# Altium schematic sheet 5.04.51805A02_STMIOPORT.SchDoc(5.04.51805A02_STMIOPORT)
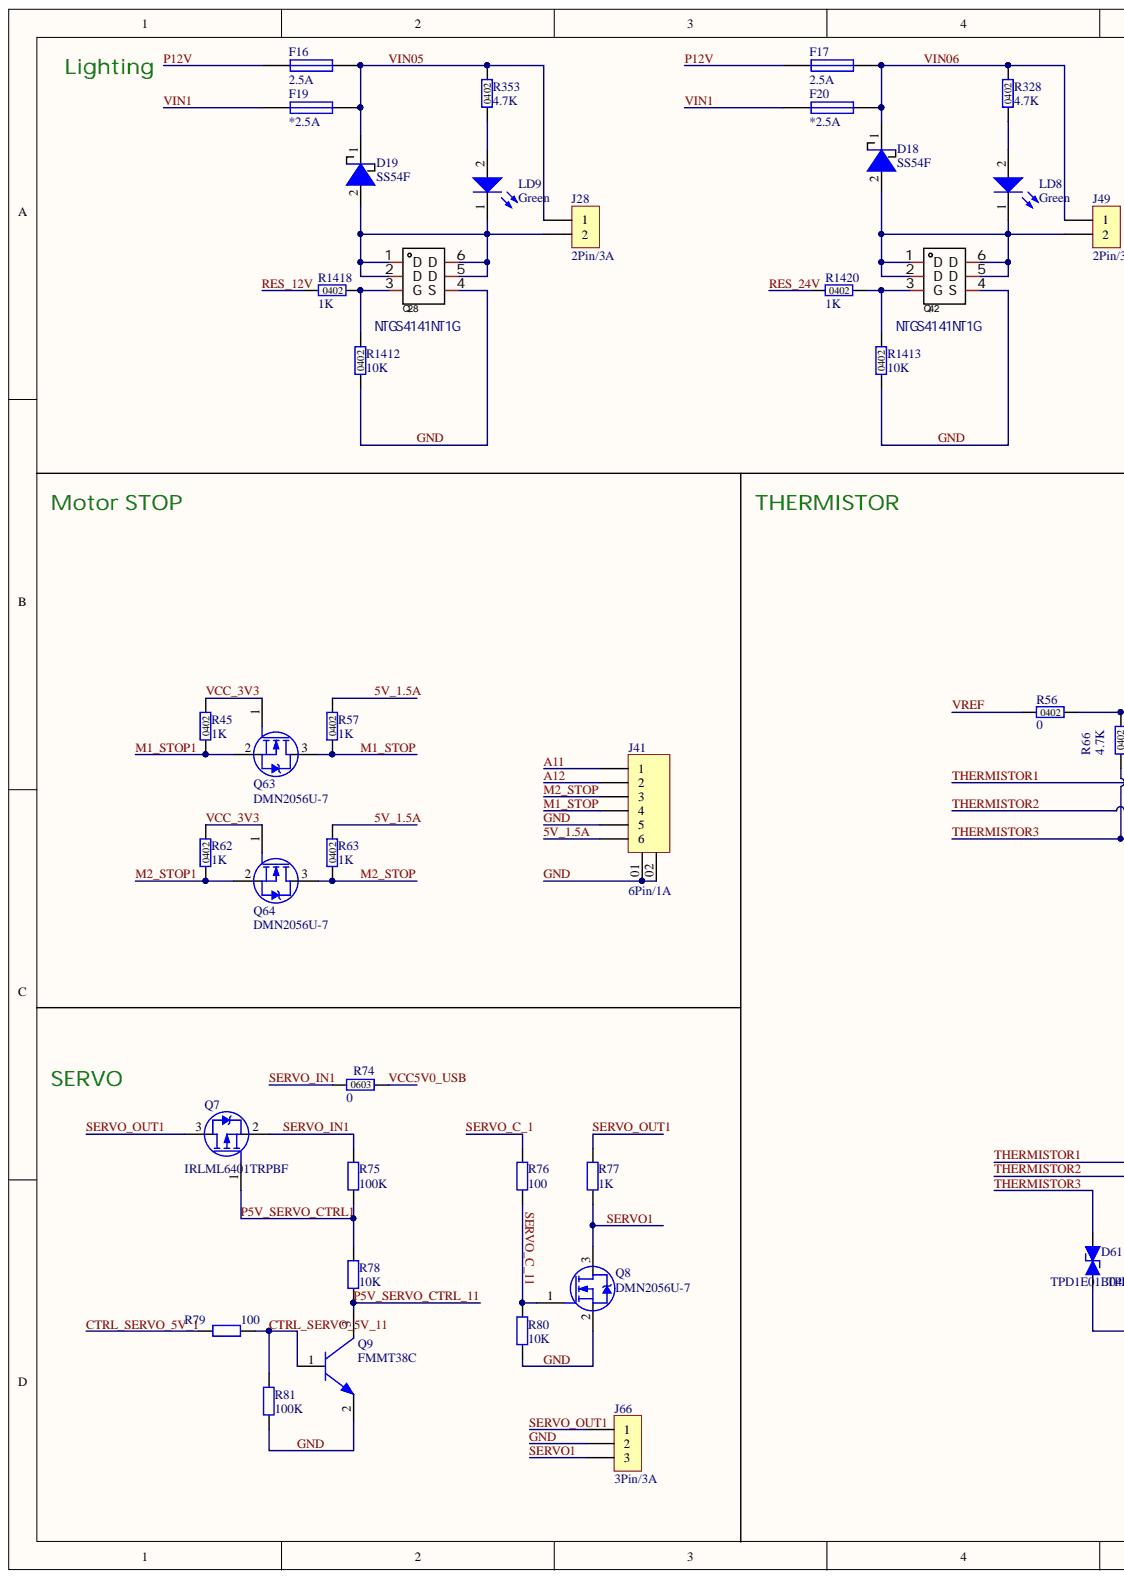
[diagram p0: 5.04.51805A02_STMIOPORT.SchDoc, left]
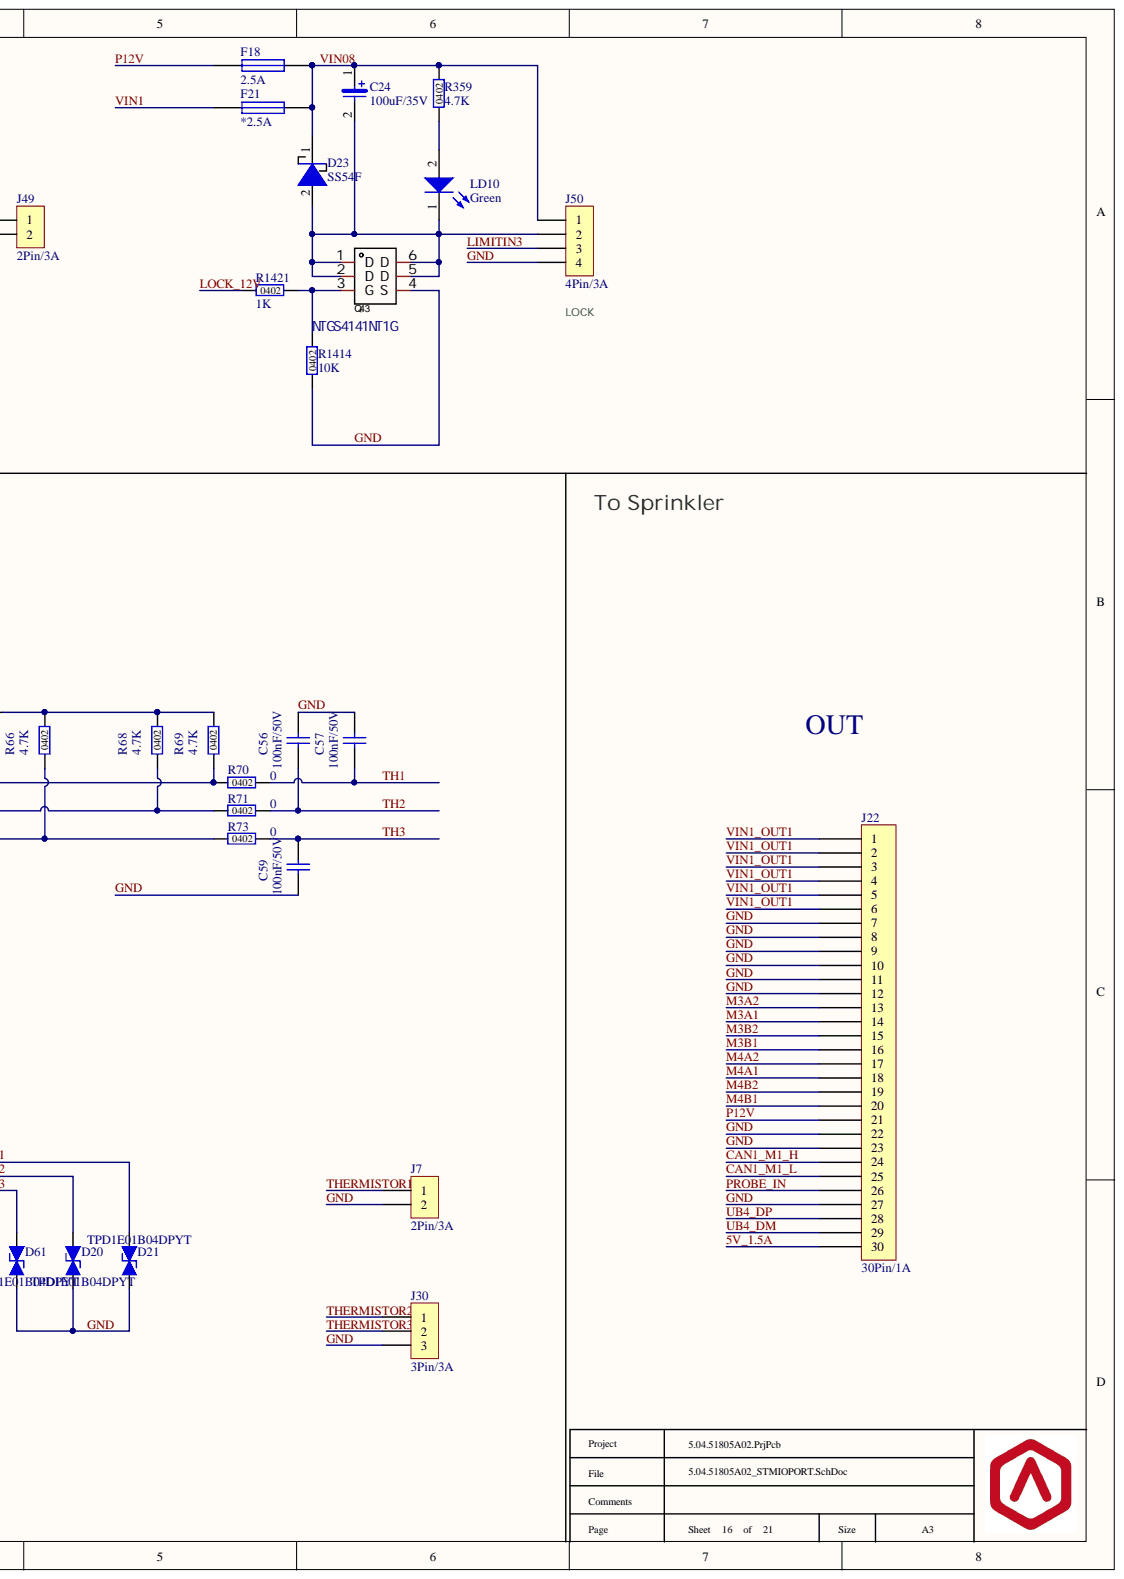
[diagram p1: 5.04.51805A02_STMIOPORT.SchDoc, right]

## Parts
C24  100uF/35V  [ALC_260_260_307]
C56  100nF/50V  [C0603]
C57  100nF/50V  [C0603]
C59  100nF/50V  [C0603]
D18  SS54F  [SMAF_L3.5-W2.6-LS4.7-RD]
D19  SS54F  [SMAF_L3.5-W2.6-LS4.7-RD]
D20  TPD1E01B04DPYT  [TVS_0402]
D21  TPD1E01B04DPYT  [TVS_0402]
D23  SS54F  [SMAF_L3.5-W2.6-LS4.7-RD]
D61  TPD1E01B04DPYT  [TVS_0402]
F16  2.5A  [F0603]
F17  2.5A  [F0603]
F18  2.5A  [F0603]
F19  *2.5A  [F0603]
F20  *2.5A  [F0603]
F21  *2.5A  [F0603]
J7  2Pin/3A  [B2B-XH-A(LF)(SN)]
J22  30Pin/1A  [FFC-SMD_FB35-30N0-AHK]
J28  2Pin/3A  [B2B-XH-A(LF)(SN)]
J30  3Pin/3A  [B3B-XH-A(LF)(SN)]
J41  6Pin/1A  [BM06B-GHS-TBT(LF)(SN)]
J49  2Pin/3A  [B2B-XH-A(LF)(SN)]
J50  4Pin/3A  [B4B-XH-A(LF)(SN)]
J66  3Pin/3A  [B3B-XH-A(LF)(SN)]
LD8  Green  [LED0603_2]
LD9  Green  [LED0603_2]
LD10  Green  [LED0603_2]
Q7  IRLML6401TRPBF  [SOT-23-3]
Q8  DMN2056U-7  [SOT-23-3]
Q9  FMMT38C  [SOT-23-3]
Q28  NTGS4141NT1G  [TSOP-6]
Q42  NTGS4141NT1G  [TSOP-6]
Q43  NTGS4141NT1G  [TSOP-6]
Q63  DMN2056U-7  [SOT-23-3]
Q64  DMN2056U-7  [SOT-23-3]
R45  1K  [R0402]
R56  0  [R0402]
R57  1K  [R0402]
R62  1K  [R0402]
R63  1K  [R0402]
R66  4.7K  [R0402]
R68  4.7K  [R0402]
R69  4.7K  [R0402]
R70  0  [R0402]
R71  0  [R0402]
R73  0  [R0402]
R74  0  [R0603]
R75  100K  [R0402]
R76  100  [R0402]
R77  1K  [R0402]
R78  10K  [R0402]
R79  100  [R0402]
R80  10K  [R0402]
R81  100K  [R0402]
R328  4.7K  [R0402]
R353  4.7K  [R0402]
R359  4.7K  [R0402]
R1412  10K  [R0402]
R1413  10K  [R0402]
R1414  10K  [R0402]
R1418  1K  [R0402]
R1420  1K  [R0402]
R1421  1K  [R0402]

## Nets
5V_1.5A: J22.30 J41.6 R57.2 R63.2
A11: J41.1
A12: J41.2
CAN1_M1_H: J22.24
CAN1_M1_L: J22.25
CTRL_SERVO_5V_1: R79.1
CTRL_SERVO_5V_11: Q9.1 R79.2 R81.1
GND: C56.2 C57.2 C59.1 D20.1 D21.1 D61.1 J7.2 J22.7 J22.8 J22.9 J22.10 J22.11 J22.12 J22.22 J22.23 J22.27 J30.3 J41.01 J41.02 J41.5 J50.4 J66.2 Q8.2 Q9.2 Q28.4(S) Q42.4(S) Q43.4(S) R80.1 R81.2 R1412.1 R1413.1 R1414.1
LIMITIN3: J50.3
LOCK_12V: R1421.1
M1_STOP: J41.4 Q63.3 R57.1
M1_STOP1: Q63.2 R45.1
M2_STOP: J41.3 Q64.3 R63.1
M2_STOP1: Q64.2 R62.1
M3A1: J22.14
M3A2: J22.13
M3B1: J22.16
M3B2: J22.15
M4A1: J22.18
M4A2: J22.17
M4B1: J22.20
M4B2: J22.19
P5V_SERVO_CTRL1: Q7.1 R75.1 R78.2
P5V_SERVO_CTRL_11: Q9.3 R78.1
P12V: F16.1 F17.1 F18.1 J22.21
PROBE_IN: J22.26
RES_12V: R1418.1
RES_24V: R1420.1
SERVO1: J66.3 Q8.3 R77.1
SERVO_C_1: R76.1
SERVO_C_11: Q8.1 R76.2 R80.2
SERVO_IN1: Q7.2 R74.1 R75.2
SERVO_OUT1: J66.1 Q7.3 R77.2
TH1: C57.1 R70.2
TH2: C56.1 R71.2
TH3: C59.2 R73.2
THERMISTOR1: D21.2 J7.1 R69.1 R70.1
THERMISTOR2: D20.2 J30.1 R68.1 R71.1
THERMISTOR3: D61.2 J30.2 R66.1 R73.1
UB4_DM: J22.29
UB4_DP: J22.28
VCC5V0_USB: R74.2
VCC_3V3: Q63.1 Q64.1 R45.2 R62.2
VIN1: F19.1 F20.1 F21.1
VIN1_OUT1: J22.1 J22.2 J22.3 J22.4 J22.5 J22.6
VIN05: D19.1 F16.2 F19.2 J28.1 R353.2
VIN06: D18.1 F17.2 F20.2 J49.1 R328.2
VIN08: C24.1 D23.1 F18.2 F21.2 J50.1 R359.2
VREF: R56.1
NetC24_2: C24.2 D23.2 J50.2 LD10.1 Q43.1(D) Q43.2(D) Q43.5(D) Q43.6(D)
NetD18_2: D18.2 J49.2 LD8.1 Q42.1(D) Q42.2(D) Q42.5(D) Q42.6(D)
NetD19_2: D19.2 J28.2 LD9.1 Q28.1(D) Q28.2(D) Q28.5(D) Q28.6(D)
NetLD8_2: LD8.2 R328.1
NetLD9_2: LD9.2 R353.1
NetLD10_2: LD10.2 R359.1
NetQ28_3: Q28.3(G) R1412.2 R1418.2
NetQ42_3: Q42.3(G) R1413.2 R1420.2
NetQ43_3: Q43.3(G) R1414.2 R1421.2
NetR56_2: R56.2 R66.2 R68.2 R69.2

## Library data of the parts
C24 = ALC_100uF_35V: Aluminum Electrolytic Capacitors, 100uF, ±20%, 35V, -55°C~105°C, ALC_660_660_780; Manufacturer1: Panasonic; ManufacturerPartNumber1: EEE-FK1V101XP; Code: 51B7C107; Component Kind: Standard; Library Name: Raise3D_Capacitor.SCHLIB
C56 C57 C59 = C0603_100nF_50V: Ceramic Capacitor, 100nF, ±10%, 50V, -55°C~125°C, C0603; Manufacturer1: Yageo; ManufacturerPartNumber1: CC0603KRX7R9BB104; Code: 5192C104; Component Kind: Standard; Library Name: Raise3D_Capacitor.IntLib
D18 D19 D23 = SBD_SS54F: SBD,SS54F,40V,550mV,5A,SMB,-65°C~150°C; Manufacturer1: Diodes; Code: 530300XX; Component Kind: Standard; ManufacturerPartNumber1: SS54F; Library Name: Raise3D_Diode.IntLib
D20 D21 D61 = TVS_TPD1E01B04DPYT: TVS二极管,TPD1E01B04DPYT,3.6V,1Channel,+/-17kV,+/-15kV,X1SON-2,-40°C~125°C; Manufacturer1: TexasInstruments; Code: 53040002; Component Kind: Standard; ManufacturerPartNumber1: TPD1E01B04DPYT; Library Name: Raise3D_Diode.IntLib
F16-F21 = Fuse_2.5A: 保险丝,SF-0603S250M-2,32V,2.5A,35A,32mOhms,F0603,-55°C~125°C; Manufacturer1: Bourns; Code: 56010008; Component Kind: Standard; ManufacturerPartNumber1: SF-0603S250M-2; Library Name: Raise3D_Fuse.IntLib
J7 J28 J49 = JW_B2B-XH-A(LF)(SN): 线对板连接器,2PINS,Pitch2.5mm,TH,OR,3A,白,-25°C~85°C; Manufacturer1: JST; Code: 55010012; Component Kind: Standard; Manufacturer2: 红星辰（HXT）; ManufacturerPartNumber1: B2B-XH-A(LF)(SN); ManufacturerPartNumber2: 25000-02A-T0P; Library Name: Raise3D_Connector.IntLib
J22 = FB35-30N0-AHK: 板对板连接器,30PINS,Pitch1mm,TH,Side,1A,,-40°C~85°C; Manufacturer1: STWXE; Code: 550600XX; Component Kind: Standard; ManufacturerPartNumber1: FB35-30N0-AHK; Library Name: Raise3D_Connector.IntLib
J30 J66 = JW_B3B-XH-A(LF)(SN): 线对板连接器,3PINS,Pitch2.5mm,TH,OR,3A,白,-25°C~85°C; Manufacturer1: JST; Code: 55010013; Component Kind: Standard; Manufacturer2: 红星辰（HXT）; ManufacturerPartNumber1: B3B-XH-A(LF)(SN); ManufacturerPartNumber2: 25000-03A-T0P; Library Name: Raise3D_Connector.IntLib
J41 = JW_BM06B-GHS-TBT(LF)(SN): 线对板连接器,6PINS,Pitch1.25mm,SMT,OR,1A,象牙白,-25°C~85°C; Manufacturer1: JST; Code: 55010004; Component Kind: Standard; Manufacturer2: 健坤; ManufacturerPartNumber1: BM06B-GHS-TBT(LF)(SN); ManufacturerPartNumber2: A1253AWV-06-F1CB1-P; Library Name: Raise3D_Connector.IntLib
J50 = JW_B4B-XH-A(LF)(SN): 线对板连接器,4PINS,Pitch2.5mm,TH,OR,3A,白,-25°C~85°C; Manufacturer1: JST; Code: 55010014; Component Kind: Standard; Manufacturer2: 红星辰（HXT）; ManufacturerPartNumber1: B4B-XH-A(LF)(SN); ManufacturerPartNumber2: 25000-04A-T0P; Library Name: Raise3D_Connector.IntLib
LD8-LD10 = LED_APT1608CGCK: LED,APT1608CGCK,Green,2.5V,TOP,LED0603,-40°C~85°C; Manufacturer1: Kingbright; Code: 53010003; Component Kind: Standard; ManufacturerPartNumber1: APT1608CGCK; Library Name: Raise3D_Diode.IntLib
Q7 = PMOS_IRLML6401TRPBF: P-MOSFET, IRLML6401TRPBF, 1Channel, Vds=-12V, Id=-4.3A, Vgs=+/-8V, SOT-23,-55℃~150℃; Manufacturer1: INTERNATIONAL RECTIFIER; Code: 54020002; Component Kind: Standard; ManufacturerPartNumber1: IRLML6401TRPBF; Library Name: Raise3D_MOS&BJT.SCHLIB
Q8 Q63 Q64 = NMOS_DMN2056U-7: N-MOSFET,DMN2056U-7,1Channel,Vds=20V,Id=4A,Vgs=+/-8V,TO-236-3,-55°C~150°C; Manufacturer1: DIODES; Code: 54010011; Component Kind: Standard; ManufacturerPartNumber1: DMN2056U-7
  Q8: Library Name: Raise3D_MOS&BJT.SCHLIB
  Q63 Q64: Library Name: Raise3D_MOS&BJT.IntLib
Q9 = NPN_FMMT38C: BJT，NPN, FMMT38C, 1Channel, Vceo=60V, Id=300mA, SOT-23-3,-55℃~150℃; Manufacturer1: DIODES; Code: 54030002; Component Kind: Standard; ManufacturerPartNumber1: FMMT38C; Library Name: Raise3D_MOS&BJT.SCHLIB
Q28 Q42 Q43 = NMOS_NTGS4141NT1G: N-MOSFET,NTGS4141NT1G,1 Channel.Vds=30V,Id=5A,Vgs=+/-20V,TSOP-6,-55℃~150℃; Manufacturer1: ONSemiconductor; Code: 54010004; Component Kind: Standard; ManufacturerPartNumber1: NTGS4141NT1G; Library Name: Raise3D_MOS&BJT.IntLib
R45 R57 R62 R63 R77 R1418 R1420 R1421 = R0402_1K: Resistor 1Kohm,5%,1/16W,R0402; Manufacturer1: Yageo; ManufacturerPartNumber1: RC0402JR-071KL; Code: 5011001K; Component Kind: Standard
  R45 R57 R62 R63 R77: Library Name: Raise3D_Resistor.SCHLIB
  R1418 R1420 R1421: Library Name: Raise3D_Resistor.IntLib
R56 R70 R71 R73 = R0402_0: Resistor 0ohm,1%,1/16W,R0402; Manufacturer1: Yageo; ManufacturerPartNumber1: RC0402FR-070RL; Code: 5001000R; Component Kind: Standard; Library Name: Raise3D_Resistor.IntLib
R66 R68 R69 R328 R353 R359 = R0402_4.7K: Resistor 4.7Kohm,5%,1/16W,R0402; Manufacturer1: Yageo; ManufacturerPartNumber1: RC0402JR-074K7L; Code: 501104K7; Component Kind: Standard; Manufacturer2: Samsung; ManufacturerPartNumber2: RCS1005J472CS; Library Name: Raise3D_Resistor.IntLib
R74 = R0603_0: Resistor  0ohm,1%,1/10W,R0603; Manufacturer1: Yageo; ManufacturerPartNumber1: RC0603FR-070RL; Code: 5002000R; Component Kind: Standard; Library Name: Raise3D_Resistor.IntLib
R75 R81 = R0402_100K: Resistor 100Kohm,1%,1/16W,R0402; Manufacturer1: Yageo; ManufacturerPartNumber1: RC0402FR-07100KL; Code: 5001100K; Component Kind: Standard; Manufacturer2: Samsung; ManufacturerPartNumber2: RC1005F104CS; Library Name: Raise3D_Resistor.SCHLIB
R76 R79 = R0402_100: Resistor 100ohm,1%,1/16W,R0402; Manufacturer1: Yageo; ManufacturerPartNumber1: RC0402FR-07100RL; Code: 5001100R; Component Kind: Standard; Manufacturer2: Samsung; ManufacturerPartNumber2: RC1005F101CS; Library Name: Raise3D_Resistor.SCHLIB
R78 R80 R1412-R1414 = R0402_10K: Resistor 10Kohm,1%,1/16W,R0402; Manufacturer1: Yageo; ManufacturerPartNumber1: RC0402FR-0710KL; Code: 5001010K; Component Kind: Standard; Manufacturer2: Samsung; ManufacturerPartNumber2: RC1005F103CS
  R78 R80: Library Name: Raise3D_Resistor.SCHLIB
  R1412-R1414: Library Name: Raise3D_Resistor.IntLib
